# Altium schematic sheet OTHER.SchDoc
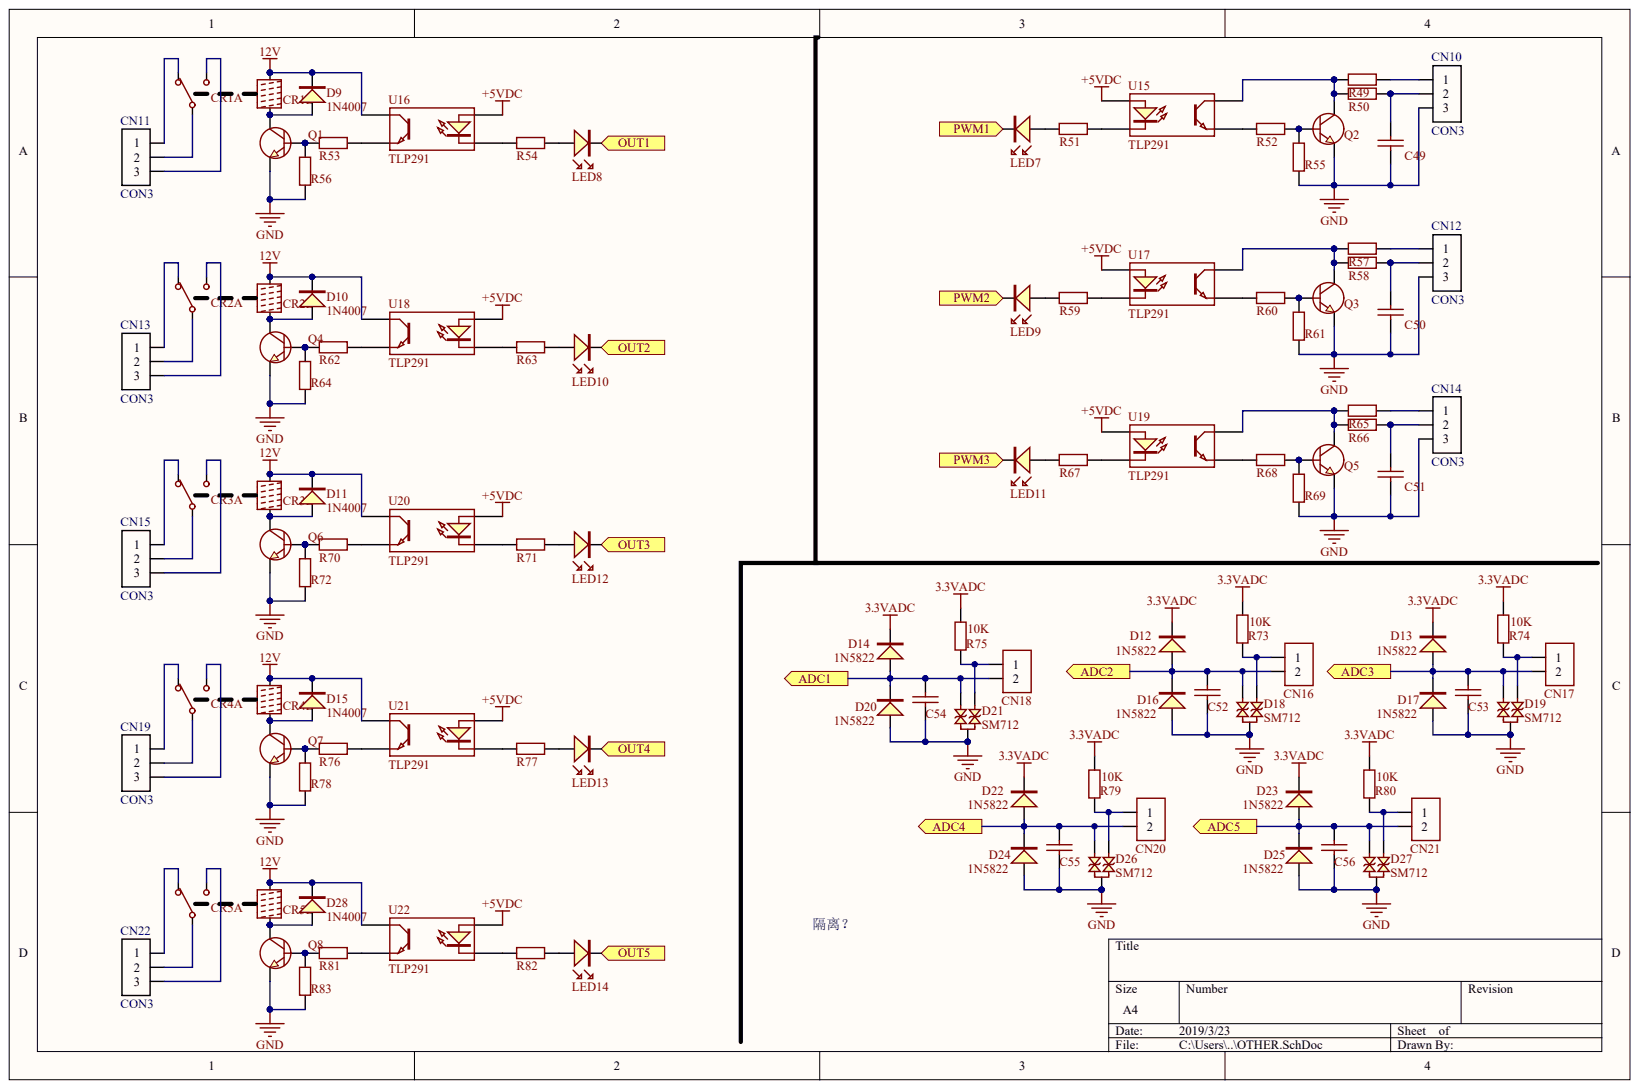
[diagram p0: OTHER.SchDoc]

## Parts
C49  CAP  (value not drawn)
C50  CAP  (value not drawn)
C51  CAP  (value not drawn)
C52  CAP  (value not drawn)
C53  CAP  (value not drawn)
C54  CAP  (value not drawn)
C55  CAP  (value not drawn)
C56  CAP  (value not drawn)
CN10  CON3
CN11  CON3
CN12  CON3
CN13  CON3
CN14  CON3
CN15  CON3
CN16  CON2  (value not drawn)
CN17  CON2  (value not drawn)
CN18  CON2  (value not drawn)
CN19  CON3
CN20  CON2  (value not drawn)
CN21  CON2  (value not drawn)
CN22  CON3
CR1  继电器  (value not drawn)  (parts A,B)
CR2  继电器  (value not drawn)  (parts A,B)
CR3  继电器  (value not drawn)  (parts A,B)
CR4  继电器  (value not drawn)  (parts A,B)
CR5  继电器  (value not drawn)  (parts A,B)
D9  1N4007
D10  1N4007
D11  1N4007
D12  1N5822
D13  1N5822
D14  1N5822
D15  1N4007
D16  1N5822
D17  1N5822
D18  SM712
D19  SM712
D20  1N5822
D21  SM712
D22  1N5822
D23  1N5822
D24  1N5822
D25  1N5822
D26  SM712
D27  SM712
D28  1N4007
LED7  Led  (value not drawn)
LED8  Led  (value not drawn)
LED9  Led  (value not drawn)
LED10  Led  (value not drawn)
LED11  Led  (value not drawn)
LED12  Led  (value not drawn)
LED13  Led  (value not drawn)
LED14  Led  (value not drawn)
Q1  1318  (value not drawn)
Q2  1318  (value not drawn)
Q3  1318  (value not drawn)
Q4  1318  (value not drawn)
Q5  1318  (value not drawn)
Q6  1318  (value not drawn)
Q7  1318  (value not drawn)
Q8  1318  (value not drawn)
R49  10K  (value not drawn)
R50  10K  (value not drawn)
R51  RES  (value not drawn)
R52  10K  (value not drawn)
R53  10K  (value not drawn)
R54  RES  (value not drawn)
R55  10K  (value not drawn)
R56  10K  (value not drawn)
R57  10K  (value not drawn)
R58  10K  (value not drawn)
R59  RES  (value not drawn)
R60  10K  (value not drawn)
R61  10K  (value not drawn)
R62  10K  (value not drawn)
R63  RES  (value not drawn)
R64  10K  (value not drawn)
R65  10K  (value not drawn)
R66  10K  (value not drawn)
R67  RES  (value not drawn)
R68  10K  (value not drawn)
R69  10K  (value not drawn)
R70  10K  (value not drawn)
R71  RES  (value not drawn)
R72  10K  (value not drawn)
R73  10K
R74  10K
R75  10K
R76  10K  (value not drawn)
R77  RES  (value not drawn)
R78  10K  (value not drawn)
R79  10K
R80  10K
R81  10K  (value not drawn)
R82  RES  (value not drawn)
R83  10K  (value not drawn)
U15  TLP291
U16  TLP291
U17  TLP291
U18  TLP291
U19  TLP291
U20  TLP291
U21  TLP291
U22  TLP291

## Ports
ADC1, ADC2, ADC3, ADC4, ADC5, OUT1, OUT2, OUT3, OUT4, OUT5, PWM1, PWM2, PWM3

## Nets
3.3VADC: D12.K D13.K D14.K D22.K D23.K R73.2 R74.2 R75.2 R79.2 R80.2
12V: CR1.5 CR2.5 CR3.5 CR4.5 CR5.5 D9.K D10.K D11.K D15.K D28.K U16.4 U18.4 U20.4 U21.4 U22.4
+5VDC: U15.1 U16.1 U17.1 U18.1 U19.1 U20.1 U21.1 U22.1
GND: C49.2 C50.2 C51.2 C52.2 C53.2 C54.2 C55.2 C56.2 CN10.3 CN12.3 CN14.3 D16.A D17.A D18.3 D19.3 D20.A D21.3 D24.A D25.A D26.3 D27.3 Q1.2 Q2.2 Q3.2 Q4.2 Q5.2 Q6.2 Q7.2 Q8.2 R55.1 R56.1 R61.1 R64.1 R69.1 R72.1 R78.1 R83.1
ADC1: C54.1 CN18.2 D14.A D20.K D21.1 ; port ADC1
ADC2: C52.1 CN16.2 D12.A D16.K D18.1 ; port ADC2
ADC3: C53.1 CN17.2 D13.A D17.K D19.1 ; port ADC3
ADC4: C55.1 CN20.2 D22.A D24.K D26.1 ; port ADC4
ADC5: C56.1 CN21.2 D23.A D25.K D27.1 ; port ADC5
OUT1: LED8.K ; port OUT1
OUT2: LED10.K ; port OUT2
OUT3: LED12.K ; port OUT3
OUT4: LED13.K ; port OUT4
OUT5: LED14.K ; port OUT5
PWM1: LED7.K ; port PWM1
PWM2: LED9.K ; port PWM2
PWM3: LED11.K ; port PWM3
NetC49_1: C49.1 CN10.2 R50.2
NetC50_1: C50.1 CN12.2 R58.2
NetC51_1: C51.1 CN14.2 R66.2
NetCN10_1: CN10.1 R49.2
NetCN11_1: CN11.1 CR1.2
NetCN11_2: CN11.2 CR1.4
NetCN11_3: CN11.3 CR1.3
NetCN12_1: CN12.1 R57.2
NetCN13_1: CN13.1 CR2.2
NetCN13_2: CN13.2 CR2.4
NetCN13_3: CN13.3 CR2.3
NetCN14_1: CN14.1 R65.2
NetCN15_1: CN15.1 CR3.2
NetCN15_2: CN15.2 CR3.4
NetCN15_3: CN15.3 CR3.3
NetCN16_1: CN16.1 D18.2 R73.1
NetCN17_1: CN17.1 D19.2 R74.1
NetCN18_1: CN18.1 D21.2 R75.1
NetCN19_1: CN19.1 CR4.2
NetCN19_2: CN19.2 CR4.4
NetCN19_3: CN19.3 CR4.3
NetCN20_1: CN20.1 D26.2 R79.1
NetCN21_1: CN21.1 D27.2 R80.1
NetCN22_1: CN22.1 CR5.2
NetCN22_2: CN22.2 CR5.4
NetCN22_3: CN22.3 CR5.3
NetCR1_1: CR1.1 D9.A Q1.3
NetCR2_1: CR2.1 D10.A Q4.3
NetCR3_1: CR3.1 D11.A Q6.3
NetCR4_1: CR4.1 D15.A Q7.3
NetCR5_1: CR5.1 D28.A Q8.3
NetLED7_A: LED7.A R51.2
NetLED8_A: LED8.A R54.2
NetLED9_A: LED9.A R59.2
NetLED10_A: LED10.A R63.2
NetLED11_A: LED11.A R67.2
NetLED12_A: LED12.A R71.2
NetLED13_A: LED13.A R77.2
NetLED14_A: LED14.A R82.2
NetQ1_1: Q1.1 R53.2 R56.2
NetQ2_1: Q2.1 R52.2 R55.2
NetQ2_3: Q2.3 R49.1 R50.1 U15.4
NetQ3_1: Q3.1 R60.2 R61.2
NetQ3_3: Q3.3 R57.1 R58.1 U17.4
NetQ4_1: Q4.1 R62.2 R64.2
NetQ5_1: Q5.1 R68.2 R69.2
NetQ5_3: Q5.3 R65.1 R66.1 U19.4
NetQ6_1: Q6.1 R70.2 R72.2
NetQ7_1: Q7.1 R76.2 R78.2
NetQ8_1: Q8.1 R81.2 R83.2
NetR51_1: R51.1 U15.2
NetR52_1: R52.1 U15.3
NetR53_1: R53.1 U16.3
NetR54_1: R54.1 U16.2
NetR59_1: R59.1 U17.2
NetR60_1: R60.1 U17.3
NetR62_1: R62.1 U18.3
NetR63_1: R63.1 U18.2
NetR67_1: R67.1 U19.2
NetR68_1: R68.1 U19.3
NetR70_1: R70.1 U20.3
NetR71_1: R71.1 U20.2
NetR76_1: R76.1 U21.3
NetR77_1: R77.1 U21.2
NetR81_1: R81.1 U22.3
NetR82_1: R82.1 U22.2

## Library data of the parts
C49-C56 = (no symbol name): 风华: 0603CG200J500NT; 三星: CL10C200JB8NNNC; 三星: CL10B104JB8NNNC; 风华: 0603B104J500NT
CN16-CN18 CN20 CN21 = (no symbol name): KANGNEX(康奈克斯电气): HB9500-2P 黑色
CN10-CN15 CN19 CN22 CR1-CR5 D9-D28 LED7-LED14 Q1-Q8 R49-R83 U15-U22 = (no symbol name)
  CR1-CR5: Published: 24-Mar-1999; LatestRevisionDate: 17-Jul-2002; LatestRevisionNote: Re-released for DXP Platform.; PackageReference: DIP-P8; Publisher: Altium Limited
  D18 D19 D21 D26 D27: 台湾元基: SM712
